# Altium schematic sheet Shutdown_Circuit.SchDoc
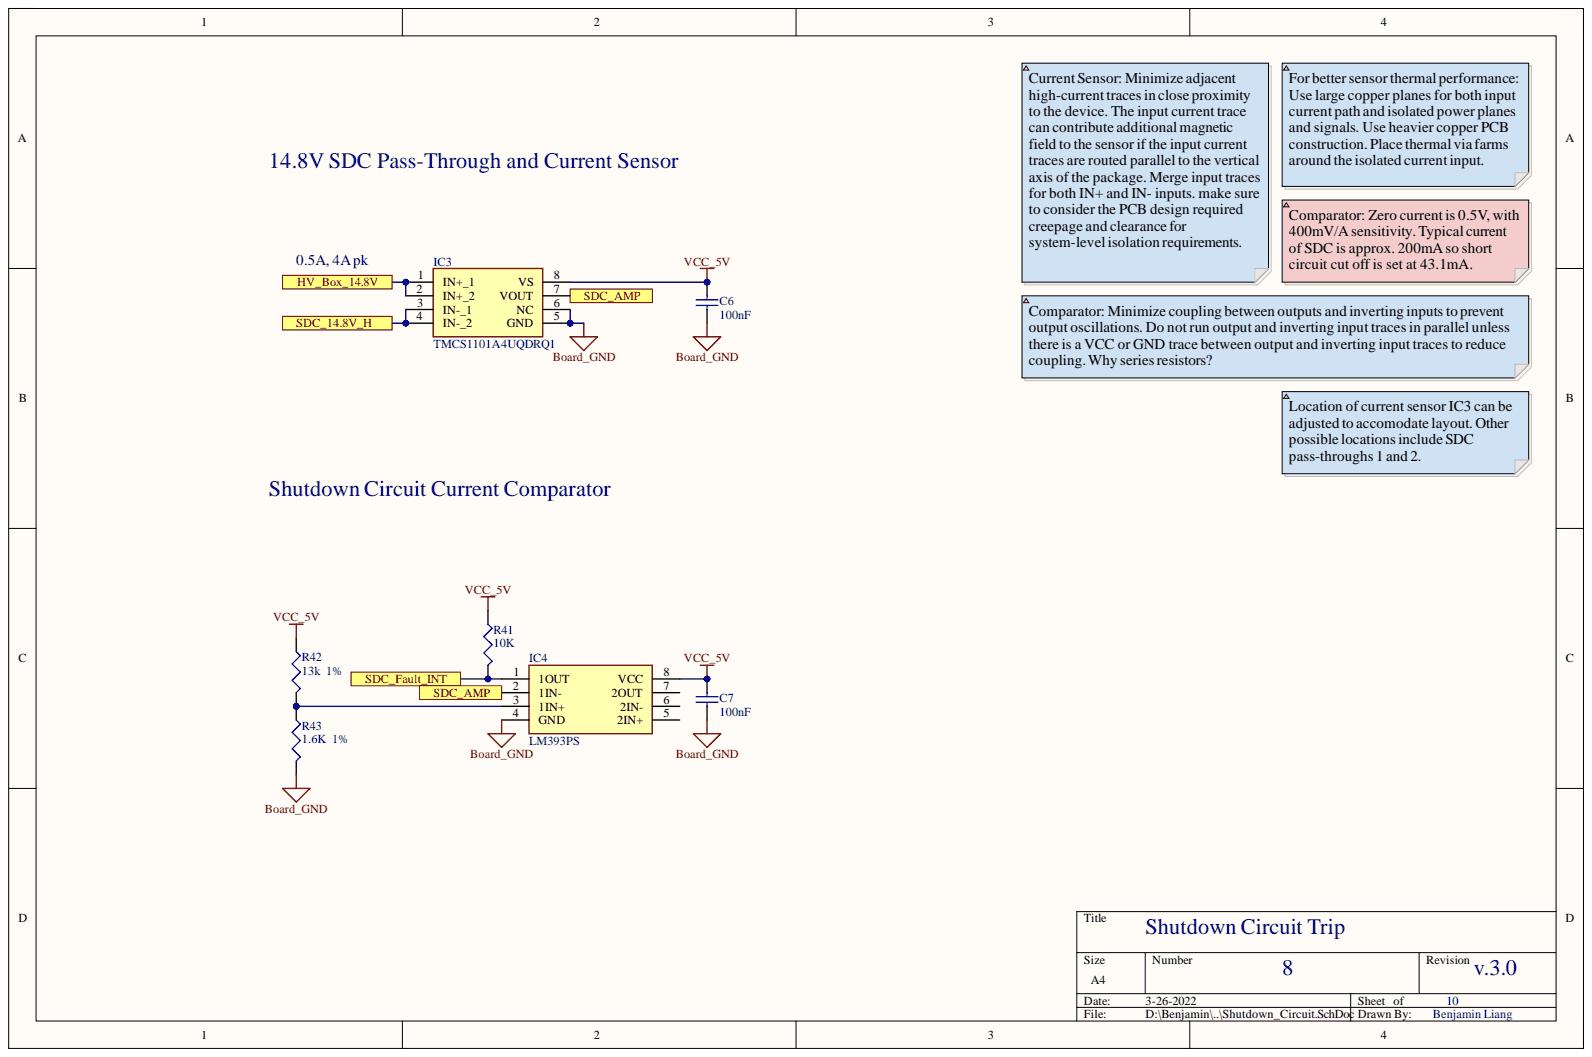
[diagram p0: Shutdown_Circuit.SchDoc]

## Parts
C6  100nF  [C1206]
C7  100nF  [C1206]
IC3  TMCS1101A4UQDRQ1  [SOIC127P600X175-8N]
IC4  LM393PS  [SOIC127P780X200-8N]
R41  10K  [J1-0603]
R42  13k  1%  [J1-0603]
R43  1.6K  1%  [J1-0603]

## Ports
HV_Box_14.8V, SDC_14.8V_H, SDC_AMP, SDC_Fault_INT

## Nets
Board_GND: C6.2 C7.2 IC3.5(GND) IC3.6(NC) IC4.4(GND) R43.2
VCC_5V: C6.1 C7.1 IC3.8(VS) IC4.8(VCC) R41.1 R42.1
HV_Box_14.8V: IC3.1(IN+_1) IC3.2(IN+_2) ; port HV_Box_14.8V
SDC_14.8V_H: IC3.3(IN-_1) IC3.4(IN-_2) ; port SDC_14.8V_H
SDC_AMP: IC3.7(VOUT) IC4.2(1IN-) ; port SDC_AMP
SDC_Fault_INT: IC4.1(1OUT) R41.2 ; port SDC_Fault_INT
NetIC4_3: IC4.3(1IN+) R42.2 R43.1

Not connected: IC4 5(2IN+) 6(2IN-) 7(2OUT)

## Library data of the parts
C6 C7 = Cap Semi: Capacitor (Semiconductor SIM Model); Component Kind: Standard; LatestRevisionDate: 29-May-2009; LatestRevisionNote: IPC-7351 Footprint Added.; Library Name: Miscellaneous Devices.IntLib; PackageDescription: Chip Capacitor; PackageReference: C1206; Published: 8-Jun-2000; Signal Integrity: Cap Semi; Simulation: CAP; Value: 100nF; Publisher: Altium Limited
IC3 = TMCS1101A4UQDRQ1: Integrated Circuit; Manufacturer_Name: Texas Instruments; Arrow Part Number: TMCS1101A4UQDRQ1; Arrow Price/Stock: https://www.arrow.com/en/products/tmcs1101a4uqdrq1/texas-instruments?region=nac; Component Kind: Standard; Datasheet Link: https://www.ti.com/lit/ds/symlink/tmcs1101-q1.pdf?HQS=dis-dk-null-digikeymode-dsf-pf-null-wwe&ts=1639364451794; Height: 1.75mm; Manufacturer_Part_Number: TMCS1101A4UQDRQ1; Mouser Part Number: 595-TMCS1101A4UQDRQ1; Mouser Price/Stock: https://www.mouser.co.uk/ProductDetail/Texas-Instruments/TMCS1101A4UQDRQ1?qs=QNEnbhJQKvYtWCVWgIciDg%3D%3D
IC4 = LM393PS: Integrated Circuit; Manufacturer_Name: Texas Instruments; Arrow Part Number: LM393PS; Arrow Price/Stock: https://www.arrow.com/en/products/lm393ps/texas-instruments; Component Kind: Standard; Datasheet Link: https://www.ti.com/lit/gpn/LM393; Height: 2mm; Manufacturer_Part_Number: LM393PS
R41-R43 = Res3: Resistor; Value: 220; Component Kind: Standard; LatestRevisionDate: 29-May-2009; LatestRevisionNote: IPC-7351 Footprint Added.; Library Name: Miscellaneous Devices.IntLib; PackageDescription: Chip Resistor; PackageReference: J1-0603; Published: 8-Jun-2000; Signal Integrity: Res; Simulation: RESISTOR; Publisher: Altium Limited
